# Altium schematic sheet Peripherals Schematic.SchDoc("Peripherals Schematic")
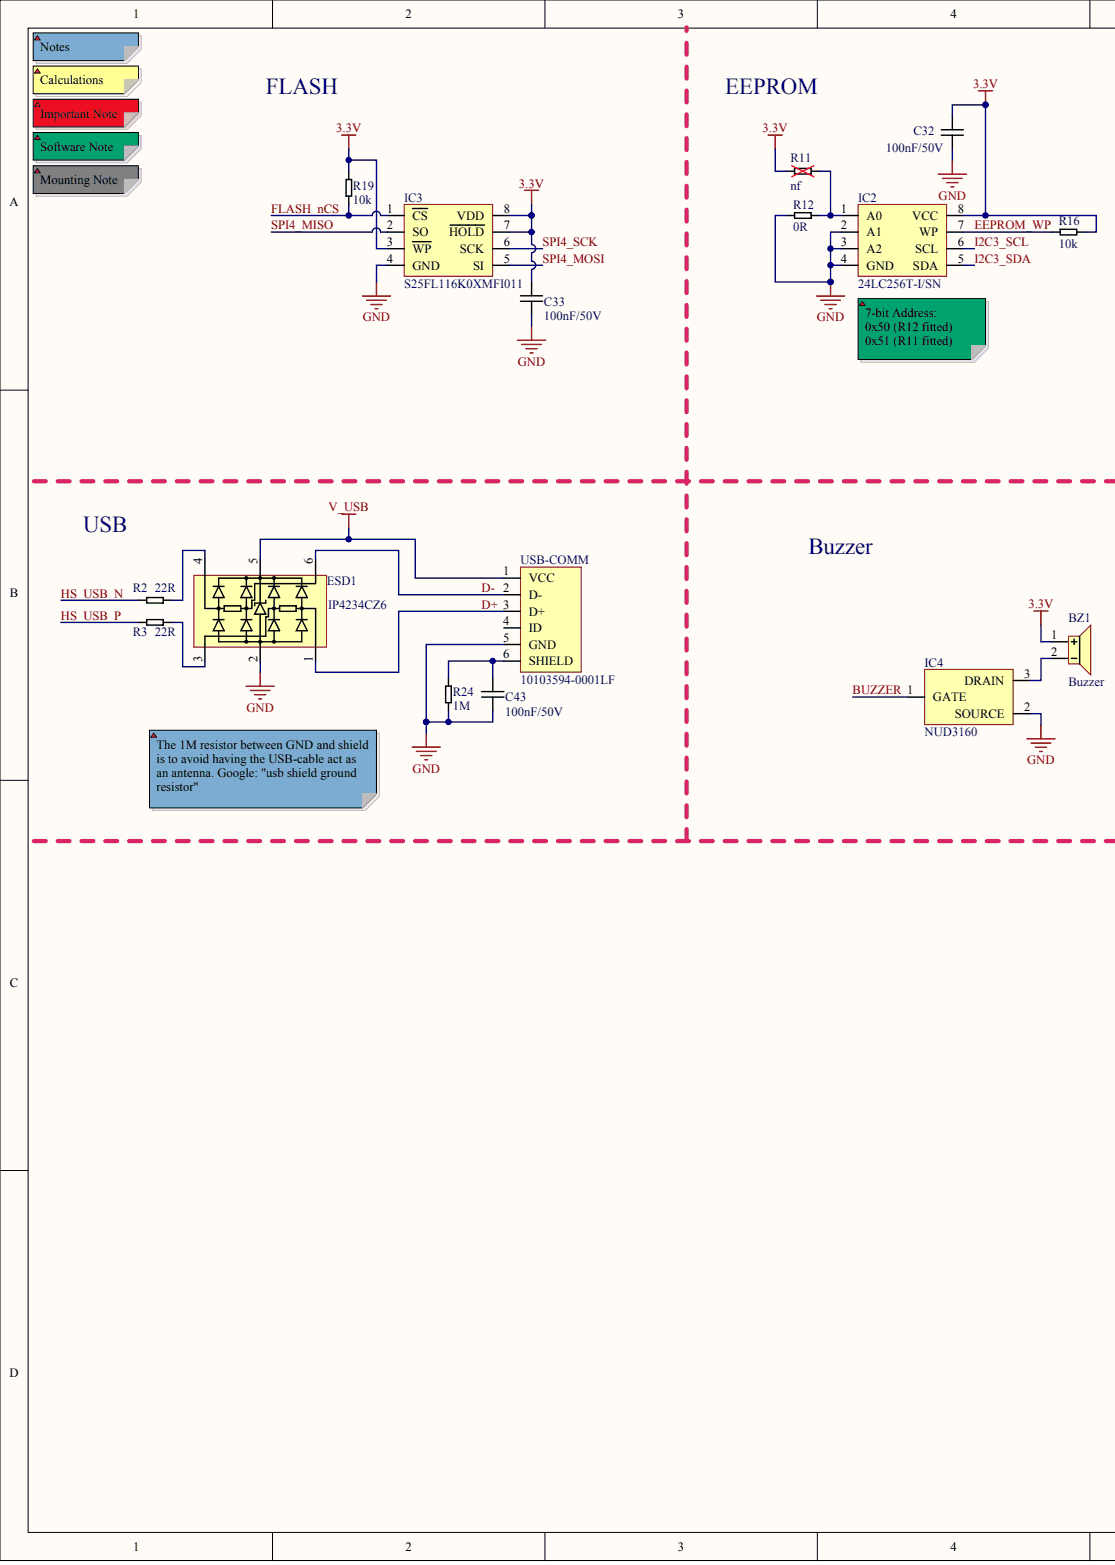
[diagram p0: Peripherals Schematic.SchDoc, left]
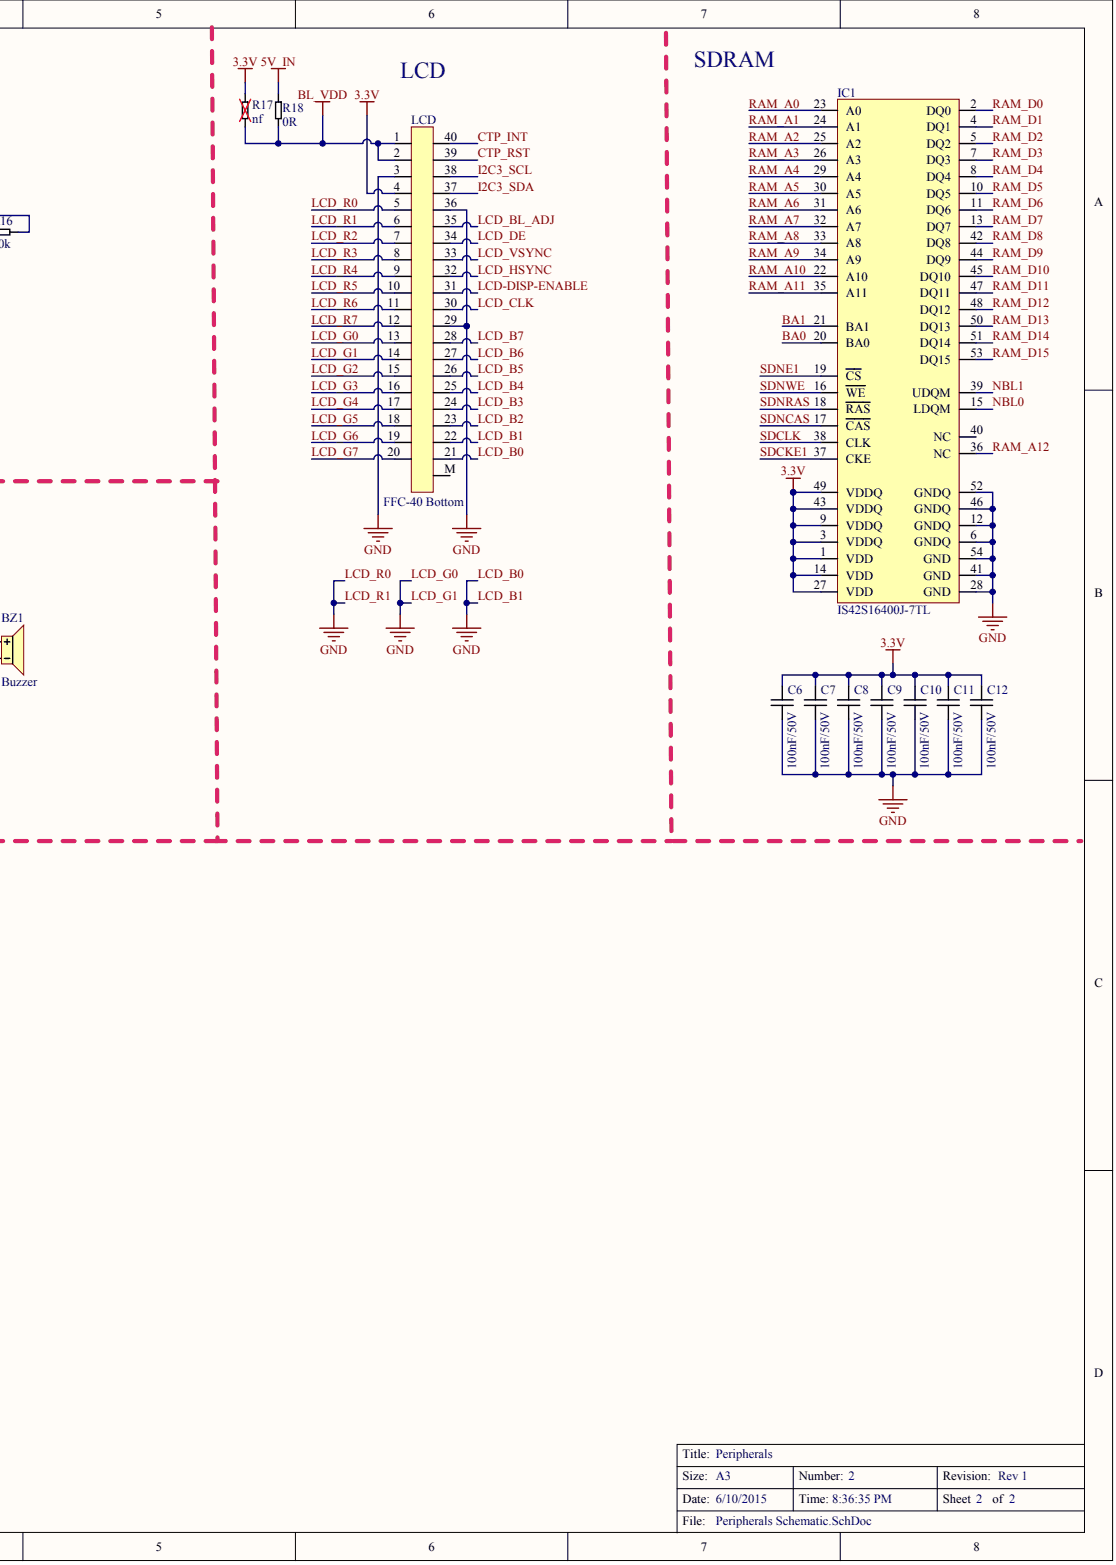
[diagram p1: Peripherals Schematic.SchDoc, right]

## Parts
BZ1  value Buzzer  (hidden comment: Piezo Buzzer 5x5mm SMD)
C6  value 100nF/50V  [0603]  (hidden comment: Cap_0603_100nF/50V_X7R)
C7  value 100nF/50V  [0603]  (hidden comment: Cap_0603_100nF/50V_X7R)
C8  value 100nF/50V  [0603]  (hidden comment: Cap_0603_100nF/50V_X7R)
C9  value 100nF/50V  [0603]  (hidden comment: Cap_0603_100nF/50V_X7R)
C10  value 100nF/50V  [0603]  (hidden comment: Cap_0603_100nF/50V_X7R)
C11  value 100nF/50V  [0603]  (hidden comment: Cap_0603_100nF/50V_X7R)
C12  value 100nF/50V  [0603]  (hidden comment: Cap_0603_100nF/50V_X7R)
C32  value 100nF/50V  [0603]  (hidden comment: Cap_0603_100nF/50V_X7R)
C33  value 100nF/50V  [0603]  (hidden comment: Cap_0603_100nF/50V)
C43  value 100nF/50V  [0603]  (hidden comment: Cap_0603_100nF/50V)
ESD1  IP4234CZ6
IC1  IS42S16400J-7TL
IC2  24LC256T-I/SN  [SOIC-8]
IC3  S25FL116K0XMFI011
IC4  NUD3160
LCD  value FFC-40 Bottom  (hidden comment: FFC 40 pin 0.5mm Bottom)
R2  value 22R  [0603]  (hidden comment: Res_0603_22R)
R3  value 22R  [0603]  (hidden comment: Res_0603_22R)
R11  value nf  [0603]  (hidden comment: Res_0603_nf)
R12  value 0R  [0603]  (hidden comment: Res_0603_0R)
R16  value 10k  [0603]  (hidden comment: Res_0603_10k)
R17  value nf  [0603]  (hidden comment: Res_0603_nf)
R18  value 0R  [0603]  (hidden comment: Res_0603_0R)
R19  value 10k  [0603]  (hidden comment: Res_0603_10k)
R24  value 1M  [0603]  (hidden comment: Res_0603_1M)
USB-COMM  value 10103594-0001LF  (hidden comment: USB Micro 10103594-0001LF)

## Nets
3.3V: BZ1.1 C6.2 C7.2 C8.2 C9.2 C10.2 C11.2 C12.2 C32.2 C33.2 IC1.1(VDD) IC1.3(VDDQ) IC1.9(VDDQ) IC1.14(VDD) IC1.27(VDD) IC1.43(VDDQ) IC1.49(VDDQ) IC2.8(VCC) IC3.3(WP) IC3.7(HOLD) IC3.8(VDD) LCD.4 R11.1 R16.1 R17.2 R19.1
5V_IN: R18.2
BL_VDD: LCD.1 LCD.2 R17.1 R18.1
V_USB: ESD1.5 USB-COMM.1(VCC)
BA0: IC1.20(BA0)
BA1: IC1.21(BA1)
BUZZER: IC4.1
CTP_INT: LCD.40
CTP_RST: LCD.39
D+: ESD1.1 USB-COMM.3(D+)
D-: ESD1.6 USB-COMM.2(D-)
EEPROM_WP: IC2.7(WP) R16.2
FLASH_NCS: IC3.1(CS) R19.2
GND: C6.1 C7.1 C8.1 C9.1 C10.1 C11.1 C12.1 C32.1 C33.1 C43.1 ESD1.2 IC1.6(GNDQ) IC1.12(GNDQ) IC1.28(GND) IC1.41(GND) IC1.46(GNDQ) IC1.52(GNDQ) IC1.54(GND) IC2.2(A1) IC2.3(A2) IC2.4(GND) IC3.4(GND) IC4.2 LCD.3 LCD.5 LCD.6 LCD.13 LCD.14 LCD.21 LCD.22 LCD.29 LCD.36 R12.1 R24.1 USB-COMM.5(GND)
HS_USB_N: R2.1
HS_USB_P: R3.1
I2C3_SCL: IC2.6(SCL) LCD.38
I2C3_SDA: IC2.5(SDA) LCD.37
LCD-DISP-ENABLE: LCD.31
LCD_B2: LCD.23
LCD_B3: LCD.24
LCD_B4: LCD.25
LCD_B5: LCD.26
LCD_B6: LCD.27
LCD_B7: LCD.28
LCD_BL_ADJ: LCD.35
LCD_CLK: LCD.30
LCD_DE: LCD.34
LCD_G2: LCD.15
LCD_G3: LCD.16
LCD_G4: LCD.17
LCD_G5: LCD.18
LCD_G6: LCD.19
LCD_G7: LCD.20
LCD_HSYNC: LCD.32
LCD_R2: LCD.7
LCD_R3: LCD.8
LCD_R4: LCD.9
LCD_R5: LCD.10
LCD_R6: LCD.11
LCD_R7: LCD.12
LCD_VSYNC: LCD.33
NBL0: IC1.15(LDQM)
NBL1: IC1.39(UDQM)
RAM_A0: IC1.23(A0)
RAM_A1: IC1.24(A1)
RAM_A2: IC1.25(A2)
RAM_A3: IC1.26(A3)
RAM_A4: IC1.29(A4)
RAM_A5: IC1.30(A5)
RAM_A6: IC1.31(A6)
RAM_A7: IC1.32(A7)
RAM_A8: IC1.33(A8)
RAM_A9: IC1.34(A9)
RAM_A10: IC1.22(A10)
RAM_A11: IC1.35(A11)
RAM_A12: IC1.36(NC)
RAM_D0: IC1.2(DQ0)
RAM_D1: IC1.4(DQ1)
RAM_D2: IC1.5(DQ2)
RAM_D3: IC1.7(DQ3)
RAM_D4: IC1.8(DQ4)
RAM_D5: IC1.10(DQ5)
RAM_D6: IC1.11(DQ6)
RAM_D7: IC1.13(DQ7)
RAM_D8: IC1.42(DQ8)
RAM_D9: IC1.44(DQ9)
RAM_D10: IC1.45(DQ10)
RAM_D11: IC1.47(DQ11)
RAM_D12: IC1.48(DQ12)
RAM_D13: IC1.50(DQ13)
RAM_D14: IC1.51(DQ14)
RAM_D15: IC1.53(DQ15)
SDCKE1: IC1.37(CKE)
SDCLK: IC1.38(CLK)
SDNCAS: IC1.17(CAS)
SDNE1: IC1.19(CS)
SDNRAS: IC1.18(RAS)
SDNWE: IC1.16(WE)
SPI4_MISO: IC3.2(SO)
SPI4_MOSI: IC3.5(SI)
SPI4_SCK: IC3.6(SCK)
NetBZ1_2: BZ1.2 IC4.3
NetC43_2: C43.2 R24.2 USB-COMM.6(SHIELD)
NetESD1_3: ESD1.3 R3.2
NetESD1_4: ESD1.4 R2.2
NetIC2_1: IC2.1(A0) R11.2 R12.2

Not connected: IC1 40(NC); LCD M; USB-COMM 4(ID)

## Library data of the parts
BZ1 = (no symbol name): Manufacturer: PUI Audio, Inc.; Part No.: SMT-0540-S-R; Mouser: 665-SMT0540SR; DigiKey: 668-1060-1-ND; Price SEK (No VAT): 30; Datasheet: http://www.puiaudio.com/pdf/SMT-0540-S-R.pdf
C6-C12 C32 C43 = (no symbol name): Part No.: C1608X7R1H104K080AA; Manufacturer: TDK; RS: 741-4459 (500-pack); DigiKey: 445-1314-1-ND; Mouser: 810-C1608X7R1H104K; Price SEK (No VAT): 0,2
ESD1 = (no symbol name): Manufacturer: NXP; Part No.: IP4234CZ6,125; Mouser: 771-IP4234CZ6125; DigiKey: 568-5869-1-ND; Price SEK (No VAT): 3,5; Value: IP4234CZ6
IC1 = (no symbol name): Manufacturer: ISSI; DigiKey: 706-1133-ND; Part No.: IS42S16400J-7TL; Price SEK (No VAT): 27; Datasheet: http://www.issi.com/WW/pdf/42-45S16400J.pdf; Mouser: 870-IS42S16400J-7TL; Value: IS42S16400J-7TL
IC2 = (no symbol name): Manufacturer: Microchip; DigiKey: 24LC256T-I/SNCT-ND; Part No.: 24LC256T-I/SN; Price SEK (No VAT): 8; Mouser: 579-24LC256T-I/SN; Value: 24LC256T-I/SN
IC3 = (no symbol name): Manufacturer: Spansion; DigiKey: 1274-1105-ND; Part No.: S25FL116K0XMFI011; Datasheet: http://www.spansion.com/Support/Datasheets/S25FL1-K_00.pdf; Mouser: 797-25FL116KOXMFI011; Price SEK (No VAT): 5; Farnell: 2363337; Value: S25FL116K0XMFI011
IC4 = (no symbol name): Part No.: NUD3160LT1G; Manufacturer: ON; DigiKey: NUD3160LT1GOSCT-ND; Price SEK (No VAT): 5; Datasheet: http://www.onsemi.com/pub_link/Collateral/NUD3160-D.PDF; Mouser: 863-NUD3160LT1G; Value: NUD3160
LCD = (no symbol name): Part No.: 54132-4062; Manufacturer: Molex; RS: 670-2639; Mouser: 538-54132-4062; DigiKey: WM3436CT-ND; Farnell: *; Price SEK (No VAT): 22
R2 R3 = (no symbol name): Part No.: CRCW060322R0FKEA; Manufacturer: Vishay; Price SEK (No VAT): 0,1; Mouser: 71-CRCW0603-22-E3; DigiKey: 541-22.0HCT-ND
R12 R18 = (no symbol name): Part No.: CRCW06030000Z0EA; Manufacturer: Vishay; Price SEK (No VAT): 0,1; DigiKey: 541-0.0GCT-ND; Mouser: 71-CRCW0603-0-E3
R16 R19 = (no symbol name): Part No.: CRCW060310K0FKEA; Manufacturer: Vishay; Price SEK (No VAT): 0,1; Mouser: 71-CRCW0603-10K-E3; DigiKey: 541-10.0KHCT-ND
USB-COMM = (no symbol name): Manufacturer: FCI; RS: 714-2347; Mouser: 649-10103594-0001LF; DigiKey: 609-4050-1-ND; Farnell: 2293752; Price SEK (No VAT): 6; Part No.: 10103594-0001LF
C33 R11 R17 R24 = (no symbol name)
  R11 R17: Price SEK (No VAT): 0
  R24: Price (No VAT): 0,1
